# Altium schematic sheet Connector.SchDoc
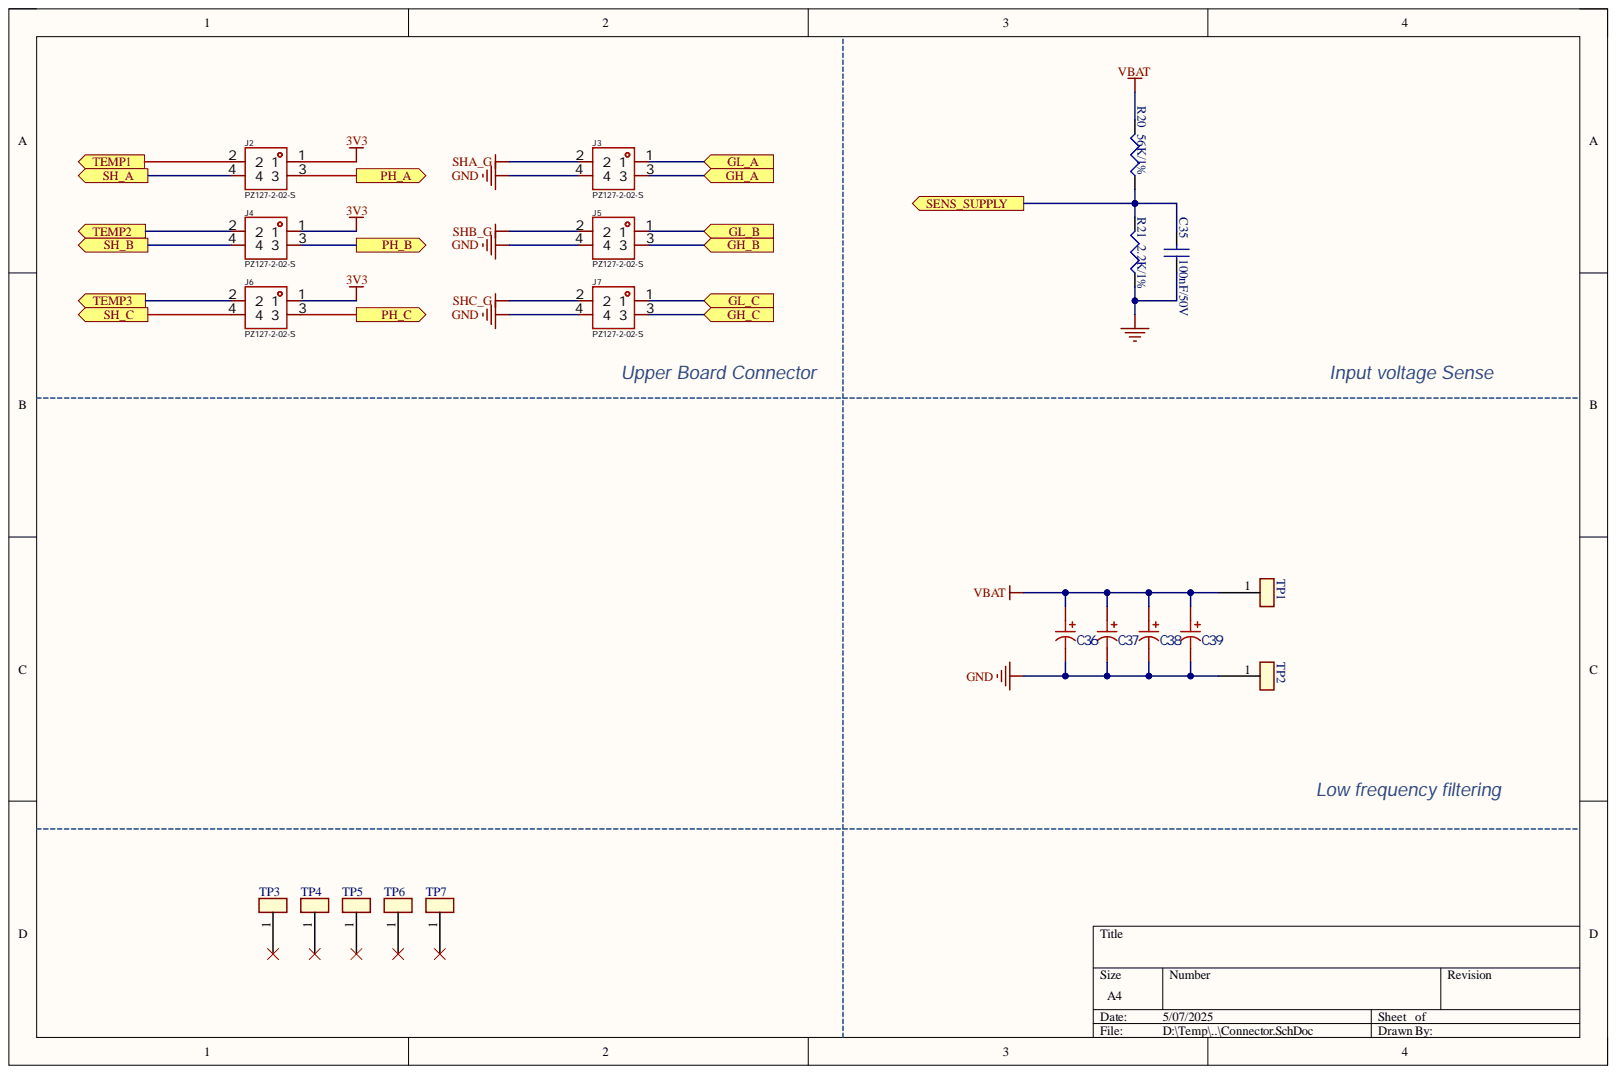
[diagram p0: Connector.SchDoc]

## Parts
C35  100nF/50V  [C0402]
C36  680uF  [CAP-TH_10.0x17mm]  (value not drawn)
C37  680uF  [CAP-TH_10.0x17mm]  (value not drawn)
C38  680uF  [CAP-TH_10.0x17mm]  (value not drawn)
C39  680uF  [CAP-TH_10.0x17mm]  (value not drawn)
J2  PZ127-2-02-S  [HDR-SMD_4P-P1.27-V-M-R2-C2-LS5.3]
J3  PZ127-2-02-S  [HDR-SMD_4P-P1.27-V-M-R2-C2-LS5.3]
J4  PZ127-2-02-S  [HDR-SMD_4P-P1.27-V-M-R2-C2-LS5.3]
J5  PZ127-2-02-S  [HDR-SMD_4P-P1.27-V-M-R2-C2-LS5.3]
J6  PZ127-2-02-S  [HDR-SMD_4P-P1.27-V-M-R2-C2-LS5.3]
J7  PZ127-2-02-S  [HDR-SMD_4P-P1.27-V-M-R2-C2-LS5.3]
R20  56K/1%  [R0402]
R21  2.2K/1%  [R0402]
TP1  TEST POINT  [HOLE-4MM_M]  (value not drawn)
TP2  TEST POINT  [HOLE-4MM_M]  (value not drawn)
TP3  TEST POINT  [HOLE-6.2MM_M]  (value not drawn)
TP4  TEST POINT  [HOLE-6.2MM_M]  (value not drawn)
TP5  TEST POINT  [HOLE-6.2MM_M]  (value not drawn)
TP6  TEST POINT  [HOLE-6.2MM_M]  (value not drawn)
TP7  TEST POINT  [HOLE-6.2MM_M]  (value not drawn)

## Ports
GH_A, GH_B, GH_C, GL_A, GL_B, GL_C, PH_A, PH_B, PH_C, SENS_SUPPLY, SH_A, SH_B, SH_C, TEMP1, TEMP2, TEMP3

## Nets
3V3: J2.1 J4.1 J6.1
GND: C35.1 C36.2 C37.2 C38.2 C39.2 J3.4 J5.4 J7.4 R21.1 TP2.1
SHA_G: J3.2
SHB_G: J5.2
SHC_G: J7.2
VBAT: C36.1 C37.1 C38.1 C39.1 R20.2 TP1.1
GH_A: J3.3 ; port GH_A
GH_B: J5.3 ; port GH_B
GH_C: J7.3 ; port GH_C
GL_A: J3.1 ; port GL_A
GL_B: J5.1 ; port GL_B
GL_C: J7.1 ; port GL_C
PH_A: J2.3 ; port PH_A
PH_B: J4.3 ; port PH_B
PH_C: J6.3 ; port PH_C
SENS_SUPPLY: C35.2 R20.1 R21.2 ; port SENS_SUPPLY
SH_A: J2.4 ; port SH_A
SH_B: J4.4 ; port SH_B
SH_C: J6.4 ; port SH_C
TEMP1: J2.2 ; port TEMP1
TEMP2: J4.2 ; port TEMP2
TEMP3: J6.2 ; port TEMP3
NetTP3_1: TP3.1
NetTP4_1: TP4.1
NetTP5_1: TP5.1
NetTP6_1: TP6.1
NetTP7_1: TP7.1

## Library data of the parts
C35 = CAP NP: Component Kind: Standard; PCB Footprint: C0402
C36-C39 = ERS1VM681G16OT: 容值:680uF;精度:±20%;额定电压:35V;纹波电流:-;等效串联电阻:-;; Manufacturer: AISHI(艾华集团); Add into BOM: yes; Rated Voltage: 35V; Tolerance: ±20%; Component Kind: Standard; Convert to PCB: yes; Datasheet: https://atta.szlcsc.com/upload/public/pdf/source/20191015/C438940_4B8E60A742BB0AE40DDEF87A5C89089D.pdf; Device: ERS1VM681G16OT; Equivalent Series Resistance(ESR): -; L: -; LCSC Part Name: 680uF ±20% 35V; Lead Spacing: -; Lifetime @ Temp: -; Manufacturer Part: ERS1VM681G16OT; Ripple Current: -; Supplier: LCSC; Supplier Footprint: 插件,D10xL16mm; Supplier Part: C721283; Symbol: ERS1VM681G16OT; Value: 680uF; φD: -; Library Name: VESC_DEVICE_Library.SchLib
J2-J7 = PZ127-2-02-S: Manufacturer: HCTL(华灿天禄); Add into BOM: yes; Circluar Pins/Square Pins: 方针; Current Rating (Max): 1A; Pin Structure: 2x2P; Pitch: 1.27mm; Soldering Temperature(Max): 260℃@10S; Color: 黑色; Component Kind: Standard; Contact Material: 黄铜; Convert to PCB: yes; Datasheet: https://atta.szlcsc.com/upload/public/pdf/source/20230609/2ACB07ADC7D4DAF6D292F3D23C037F6C.pdf; Device: PZ127-2-02-S; Insulation Height: 1.5mm; JLCPCB Part Class: Extended Part; LCSC Part Name: 2x2P 间距:1.27mm 方针 立贴; Length of End Connection Pin: -; Length of Mating Pin: 4mm; Manufacturer Part: PZ127-2-02-S; Mounting Type: 立贴; Number of Pins: 4P; Number of Rows: 双排; Operating Temperature Range: -40℃~+105℃; Row Spacing: 1.27mm; Supplier: LCSC; Supplier Footprint: SMD,P=1.27mm; Supplier Part: C3975185; Symbol: PZ127-2-02-S; Library Name: VESC_DEVICE_Library.SchLib
R20 R21 = RESISTOR: Component Kind: Standard; PCB Footprint: R0402
TP1-TP7 = TEST POINT: Component Kind: Standard; Library Name: VESC_DEVICE_Library.SchLib
